# Altium schematic sheet AutomaticFanController.SchDoc(AutomaticFanController)
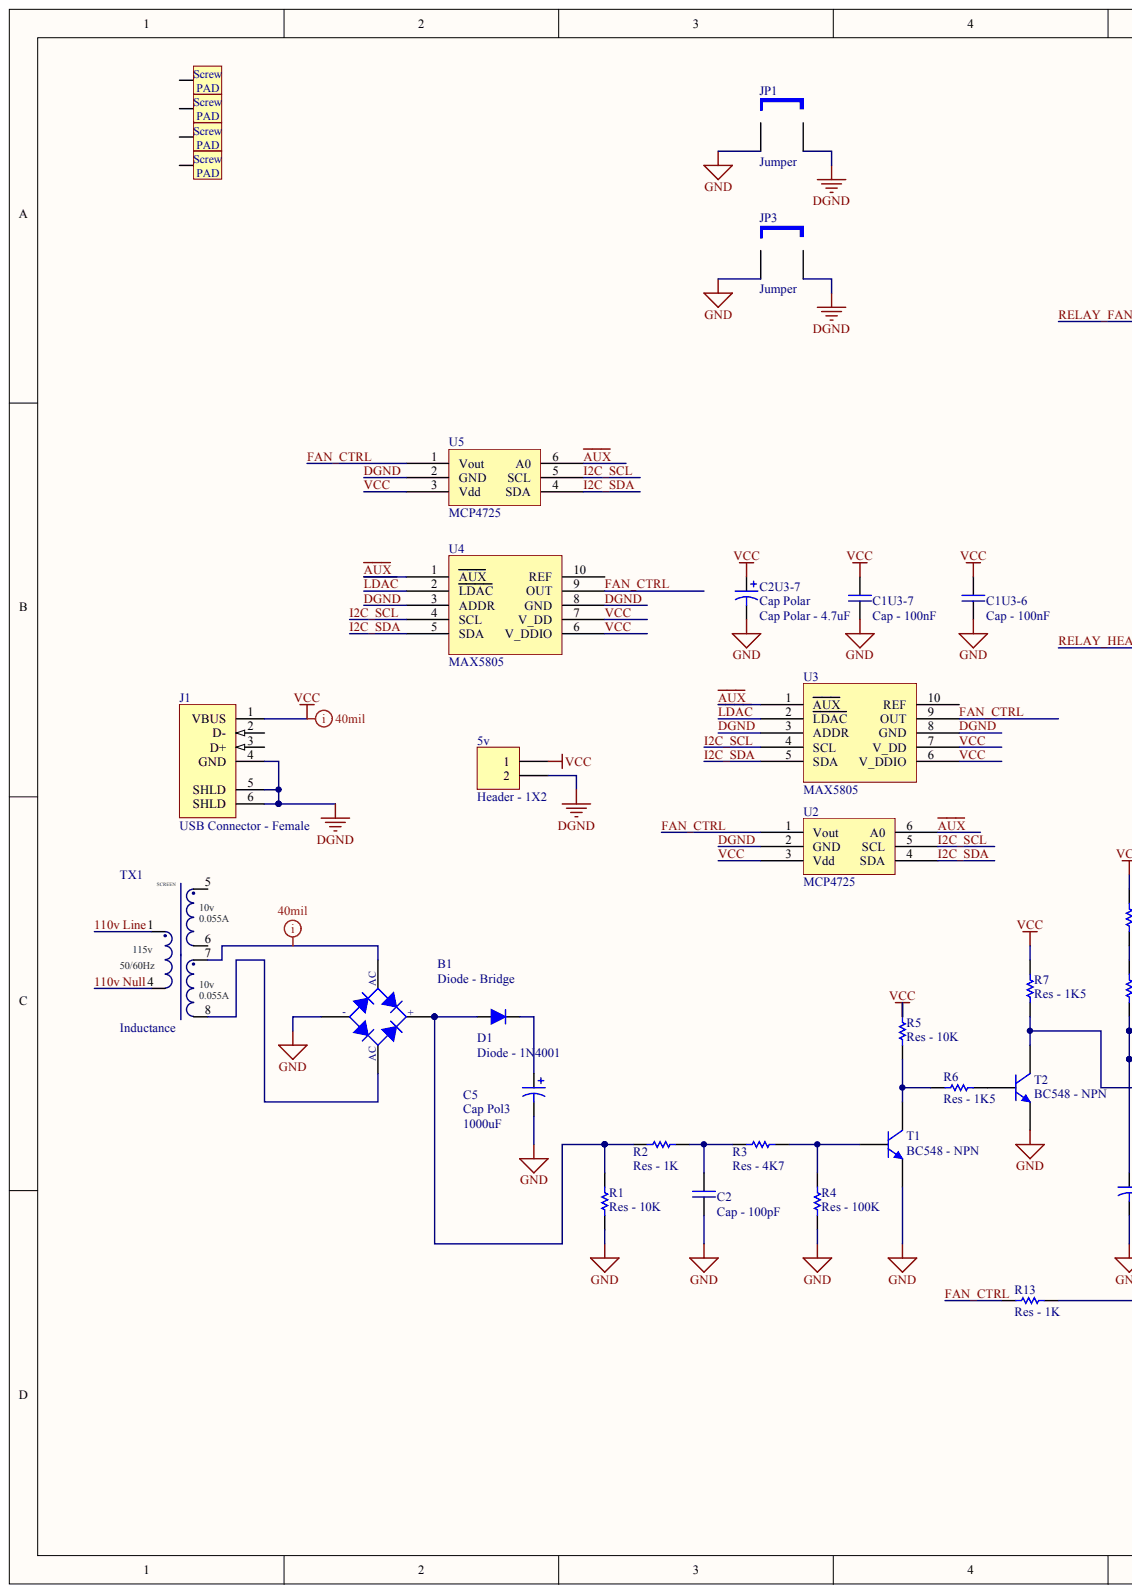
[diagram p0: AutomaticFanController.SchDoc, left]
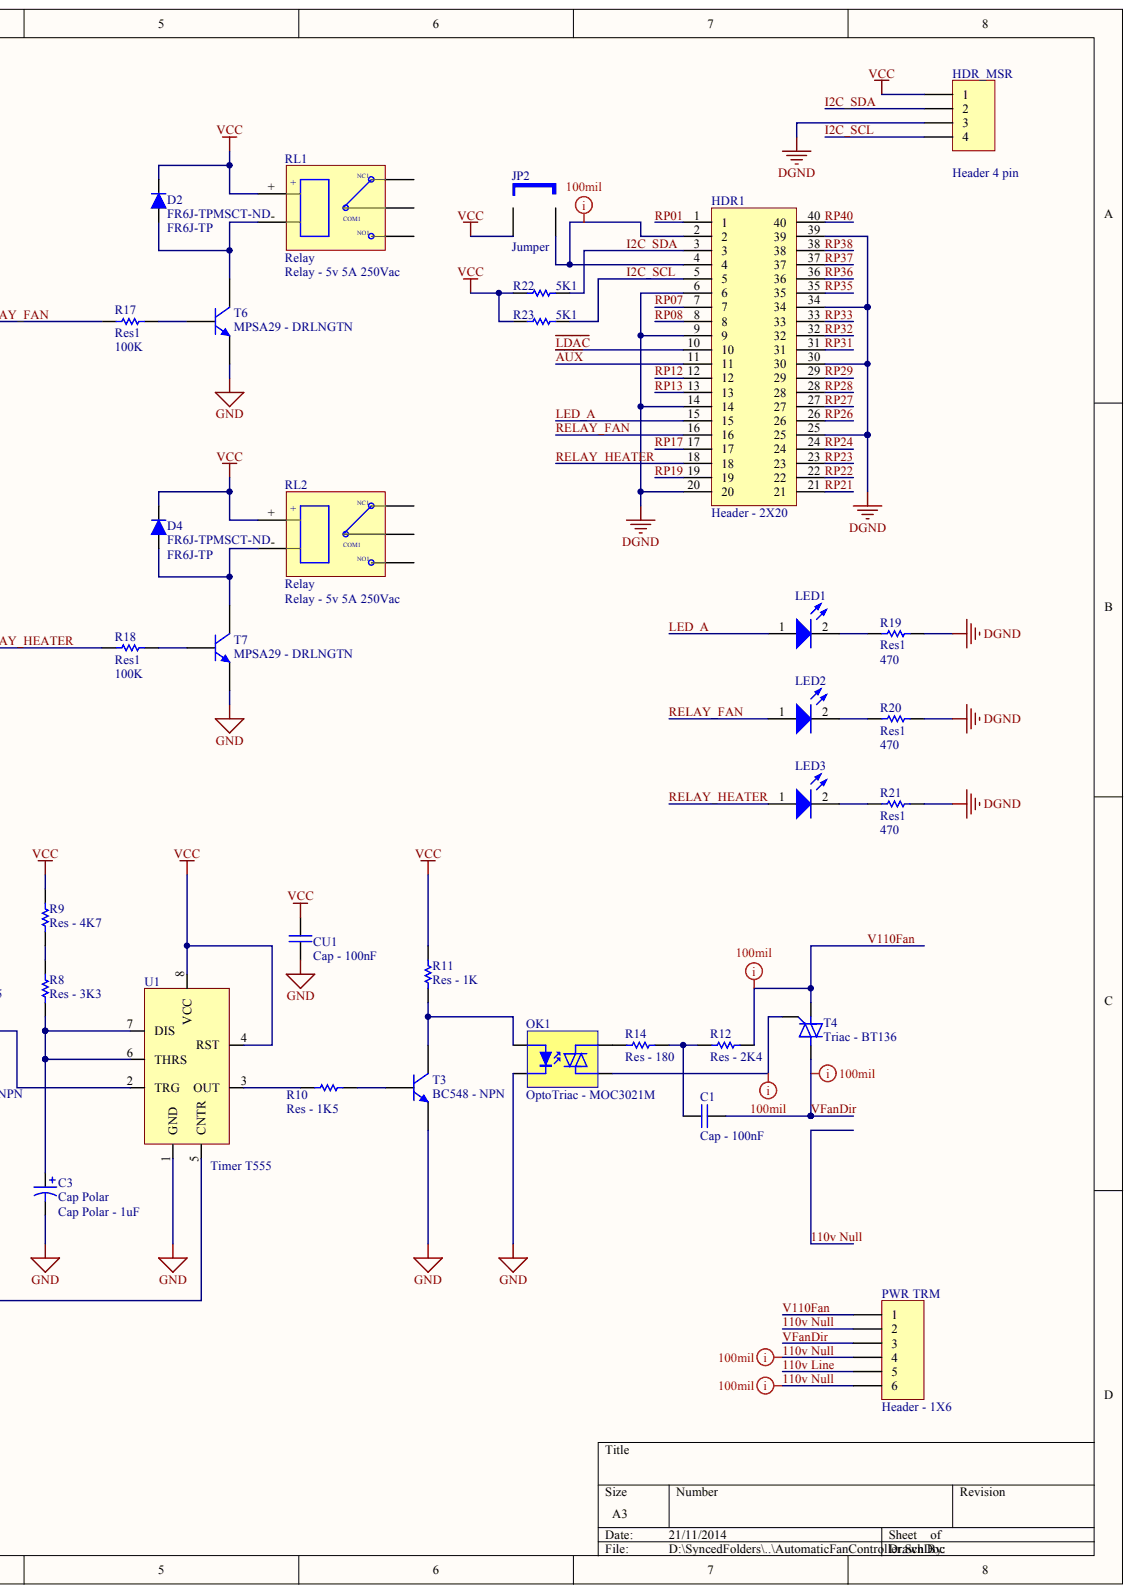
[diagram p1: AutomaticFanController.SchDoc, right]

## Parts
5v  Header - 1X2
B1  Diode - Bridge
C1  Cap  value Cap - 100nF
C1U3-6  Cap  value Cap - 100nF
C1U3-7  Cap  value Cap - 100nF
C2  Cap  value Cap - 100pF
C2U3-7  Cap Polar  value Cap Polar - 4.7uF
C3  Cap Polar  value Cap Polar - 1uF
C5  Cap Pol3  value 1000uF
CU1  Cap  value Cap - 100nF
D1  Diode  value Diode - 1N4001
D2  value FR6J-TP  Digi-Key Part Number: FR6J-TPMSCT-ND  (hidden comment: Diode Fast, Freewheeling)
D4  value FR6J-TP  Digi-Key Part Number: FR6J-TPMSCT-ND  (hidden comment: Diode Fast, Freewheeling)
HDR1  Header - 2X20
HDR_MSR  value Header 4 pin  (hidden comment: Header - 1X4)
J1  USB Connector - Female
JP1  Jumper
JP2  Jumper
JP3  Jumper
LED1  LED2
LED2  LED2
LED3  LED2  (value not drawn)
OK1  OptoTriac - MOC3021M
PWR_TRM  Header - 1X6
R1  value Res - 10K  (hidden comment: Resistor)
R2  value Res - 1K  (hidden comment: Resistor)
R3  value Res - 4K7  (hidden comment: Resistor)
R4  value Res - 100K  (hidden comment: Resistor)
R5  value Res - 10K  (hidden comment: Resistor)
R6  value Res - 1K5  (hidden comment: Resistor)
R7  value Res - 1K5  (hidden comment: Resistor)
R8  value Res - 3K3  (hidden comment: Resistor)
R9  value Res - 4K7  (hidden comment: Resistor)
R10  value Res - 1K5  (hidden comment: Resistor)
R11  value Res - 1K  (hidden comment: Resistor)
R12  value Res - 2K4  (hidden comment: Resistor)
R13  value Res - 1K  (hidden comment: Resistor)
R14  value Res - 180  (hidden comment: Resistor)
R17  Res1  value 100K
R18  Res1  value 100K
R19  Res1  value 470
R20  Res1  value 470
R21  Res1  value 470
R22  value 5K1  (hidden comment: Res1)
R23  value 5K1  (hidden comment: Res1)
RL1  Relay  value Relay - 5v 5A 250Vac
RL2  Relay  value Relay - 5v 5A 250Vac
SC1  Screw Pad  (value not drawn)
SC2  Screw Pad  (value not drawn)
SC3  Screw Pad  (value not drawn)
SC4  Screw Pad  (value not drawn)
T1  value BC548 - NPN  (hidden comment: Transistor - BC548)
T2  value BC548 - NPN  (hidden comment: Transistor - BC548)
T3  value BC548 - NPN  (hidden comment: Transistor - BC548)
T4  Triac - BT136
T6  value MPSA29 - DRLNGTN  (hidden comment: Transistor Darlington - MPSA29)
T7  value MPSA29 - DRLNGTN  (hidden comment: Transistor Darlington - MPSA29)
TX1  =Inductance
U1  Timer T555
U2  MCP4725
U3  MAX5805
U4  MAX5805
U5  MCP4725

## Nets
GND: B1.3 C1U3-6.2 C1U3-7.2 C2.2 C2U3-7.2 C3.2 C5.2 CU1.2 JP1.1 JP3.1 OK1.2 R1.1 R4.1 T1.1 T2.1 T3.1 T6.1 T7.1 U1.1(GND)
110V LINE: PWR_TRM.5 TX1.1
110V NULL: PWR_TRM.2 PWR_TRM.4 PWR_TRM.6 TX1.4
~{AUX}: HDR1.11 U2.6(A0) U3.1(AUX) U4.1(AUX) U5.6(A0)
DGND: 5v.2 HDR1.6 HDR1.9 HDR1.14 HDR1.20 HDR1.25 HDR1.30 HDR1.34 HDR1.39 HDR_MSR.3 J1.4(GND) J1.5(SHLD) J1.6(SHLD) JP1.2 JP3.2 R19.2 R20.2 R21.2 U2.2(GND) U3.3(ADDR) U3.8(GND) U4.3(ADDR) U4.8(GND) U5.2(GND)
FAN_CTRL: R13.2 U2.1(Vout) U3.9(OUT) U4.9(OUT) U5.1(Vout)
I2C_SCL: HDR1.5 HDR_MSR.4 R23.1 U2.5(SCL) U3.4(SCL) U4.4(SCL) U5.5(SCL)
I2C_SDA: HDR1.3 HDR_MSR.2 R22.1 U2.4(SDA) U3.5(SDA) U4.5(SDA) U5.4(SDA)
~{LDAC}: HDR1.10 U3.2(LDAC) U4.2(LDAC)
LED_A: HDR1.15 LED1.1
RELAY_FAN: HDR1.16 LED2.1 R17.2
RELAY_HEATER: HDR1.18 LED3.1 R18.2
RP01: HDR1.1
RP07: HDR1.7
RP08: HDR1.8
RP12: HDR1.12
RP13: HDR1.13
RP17: HDR1.17
RP19: HDR1.19
RP21: HDR1.21
RP22: HDR1.22
RP23: HDR1.23
RP24: HDR1.24
RP26: HDR1.26
RP27: HDR1.27
RP28: HDR1.28
RP29: HDR1.29
RP31: HDR1.31
RP32: HDR1.32
RP33: HDR1.33
RP35: HDR1.35
RP36: HDR1.36
RP37: HDR1.37
RP38: HDR1.38
RP40: HDR1.40
V110FAN: PWR_TRM.1 R12.2 T4.2
VCC: 5v.1 C1U3-6.1 C1U3-7.1 C2U3-7.1 CU1.1 D2.K D4.K HDR_MSR.1 J1.1(VBUS) JP2.1 R5.2 R7.2 R9.2 R11.1 R22.2 R23.2 RL1.+ RL2.+ U1.4(RST) U1.8(VCC) U2.3(Vdd) U3.6(V_DDIO) U3.7(V_DD) U4.6(V_DDIO) U4.7(V_DD) U5.3(Vdd)
VFANDIR: C1.2 PWR_TRM.3 T4.1
NetB1_1: B1.1 TX1.7
NetB1_2: B1.2 TX1.8
NetB1_4: B1.4 D1.1 R1.2 R2.1
NetC1_1: C1.1 R12.1 R14.2
NetC2_1: C2.1 R2.2 R3.1
NetC3_1: C3.1 R8.1 U1.6(THRS) U1.7(DIS)
NetC5_1: C5.1 D1.2
NetD2_A: D2.A RL1.- T6.3
NetD4_A: D4.A RL2.- T7.3
NetHDR1_2: HDR1.2 HDR1.4 JP2.2
NetLED1_2: LED1.2 R19.1
NetLED2_2: LED2.2 R20.1
NetLED3_2: LED3.2 R21.1
NetOK1_1: OK1.1 R11.2 T3.3
NetOK1_4: OK1.4 T4.3
NetOK1_6: OK1.6 R14.1
NetR3_2: R3.2 R4.2 T1.2
NetR5_1: R5.1 R6.1 T1.3
NetR6_2: R6.2 T2.2
NetR7_1: R7.1 T2.3 U1.2(TRG)
NetR8_2: R8.2 R9.1
NetR10_1: R10.1 T3.2
NetR10_2: R10.2 U1.3(OUT)
NetR13_1: R13.1 U1.5(CNTR)
NetR17_1: R17.1 T6.2
NetR18_1: R18.1 T7.2

Not connected: J1 2(D-) 3(D+); RL1 COM1 NC1 NO1; RL2 COM1 NC1 NO1; SC1 0; SC2 0; SC3 0; SC4 0; TX1 5 6; U3 10(REF); U4 10(REF)

## Library data of the parts
5v = (no symbol name): Value: Comm. Header 2 pin; Revision: 17-Jul-2002: Re-released for DXP Platform.; Digi-Key Part Number: ED2902-ND; Publisher: Altium Limited
C2U3-7 = (no symbol name): Value: Cap Polar - 4.7uF; Digi-Key Part Number: 493-5138-1-ND
C3 = (no symbol name): Value: Cap Polar - 1uF; Digi-Key Part Number: P15830CT-ND
D1 = (no symbol name): Code - JEDEC: DO-214-AB; Value: Diode - 1N4001; Published: 8-Jun-2000; Revision: July-2002: Re-released for DXP Platform.; Digi-Key Part Number: 1N4001FSCT-ND; Publisher: Altium Limited
J1 = (no symbol name): Value: USB Connector B - Female; Digi-Key Part Number: ED2989-ND; DataSheet: http://www.on-shore.com/sites/default/files/manuals/USB-A1HSXX.pdf
LED1-LED3 = (no symbol name): Manufacturer: Generic Components; Class: Optoelectronic; SubClass: LED; Published: 08/06/2000; Publisher: Altium Hobart Technology Centre
  LED1: Digi-Key Part Number: C5SMF-RJS-CT0W0BB1-ND
  LED2: Digi-Key Part Number: 1080-1012-1-ND
  LED3: Digi-Key Part Number: 160-1852-ND
PWR_TRM = (no symbol name): Value: Comm. Header 6 pin; Revision: 17-Jul-2002: Re-released for DXP Platform.; Publisher: Altium Limited
SC1-SC4 = (no symbol name): Value: No Physical Component Needed
T1 T3 = (no symbol name): Value: BC548 - NPN
TX1 = (no symbol name): Value: Transformer 115v-P10v,S20v, Low Power; Digi-Key Part Number: 237-1554-ND
B1 C1 C1U3-6 C1U3-7 C2 C5 CU1 D2 D4 HDR1 HDR_MSR JP1-JP3 OK1 R1-R14 R17-R23 RL1 RL2 T2 T4 T6 T7 U1-U5 = (no symbol name)
  B1: Value: Diode - Bridge; Digi-Key Part Number: W10M-BPMS-ND
  C1: Digi-Key Part Number: 490-7010-3-ND
  C1U3-6 C1U3-7: Digi-Key Part Number: Digi-Key Part Number
  C2: Digi-Key Part Number: 1250PH-ND
  C5: Published: 8-Jun-2000; LatestRevisionDate: 29-May-2009; LatestRevisionNote: IPC-7351 Footprint Added.; PackageReference: C0805; PackageDescription: Chip Capacitor; Digi-Key Part Number: 493-1129-ND
  CU1: Digi-Key Part Number: BC2665CT-ND
  D2 D4: Revision: July-2002: Re-released for DXP Platform.
  HDR1: Revision: 17-Jul-2002: Re-released for DXP Platform.; Digikey Link: DIP 40; : http://www.digikey.ca/product-search/en?pv563=3&FV=fff40016%2Cfff802f4&k=rectangular+female&mnonly=0&newproducts=0&ColumnSort=0&page=1&quantity=0&ptm=0&fid=0&pageSize=25; Digi-Key Part Number: ED10529-ND
  HDR_MSR: Revision: 17-Jul-2002: Re-released for DXP Platform.; Digi-Key Part Number: WM4202-ND
  JP1-JP3: Published: 24-Mar-1999; Revision: July-2002: Re-released for DXP Platform.; Value: Jumper
  OK1: Value: OptoTriac - MOC3021M
  R1 R5: Published: 8-Jun-2000; Revision: July-2002: Re-released for DXP Platform.; Digi-Key Part Number: CF14JT10K0CT-ND
  R2 R11-R14: Published: 8-Jun-2000; Revision: July-2002: Re-released for DXP Platform.; Digi-Key Part Number: CF14JT1K00CT-ND
  R3 R9: Published: 8-Jun-2000; Revision: July-2002: Re-released for DXP Platform.; Digi-Key Part Number: CF14JT4K70CT-ND
  R4: Published: 8-Jun-2000; Revision: July-2002: Re-released for DXP Platform.; Digi-Key Part Number: CF14JT100KCT-ND
  R6 R7 R10: Published: 8-Jun-2000; Revision: July-2002: Re-released for DXP Platform.; Digi-Key Part Number: CF14JT1K50CT-ND
  R8: Published: 8-Jun-2000; Revision: July-2002: Re-released for DXP Platform.; Digi-Key Part Number: CF14JT2K20CT-ND
  R17 R18: Published: 8-Jun-2000; LatestRevisionDate: 17-Jul-2002; LatestRevisionNote: Re-released for DXP Platform.; PackageReference: AXIAL-0.3; Digi-Key Part Number: CF14JT100KCT-ND
  R19-R21: Published: 8-Jun-2000; LatestRevisionDate: 17-Jul-2002; LatestRevisionNote: Re-released for DXP Platform.; PackageReference: AXIAL-0.3; Digi-Key Part Number: CF14JT470RCT-ND
  R22 R23: Published: 8-Jun-2000; LatestRevisionDate: 17-Jul-2002; LatestRevisionNote: Re-released for DXP Platform.; PackageReference: AXIAL-0.3; Digi-Key Part Number: CF14JT5K10CT-ND
  RL1 RL2: Digi-Key Part Number: T9AS1D22-5-ND
  T4: Value: Triac - BT136; Digi-Key Part Number: 568-3651-5-ND
  T6 T7: Digi-Key Part Number: MPSA29GOS-ND
  U1: Value: Timer T555; Digi-Key Part Number: LM555CNFS-ND
  U2 U5: Value: MCP4725; Digi-Key Part Number: MCP4725A0T-E/CHCT-ND
  U3 U4: Value: MAX5805; Digi-Key Part Number: MAX5805AAUB+-ND
  C5 HDR1 HDR_MSR JP1-JP3 R1-R14 R17-R23: Publisher: Altium Limited
